# Altium schematic sheet DriverBoard.SchDoc(DriverBoard)
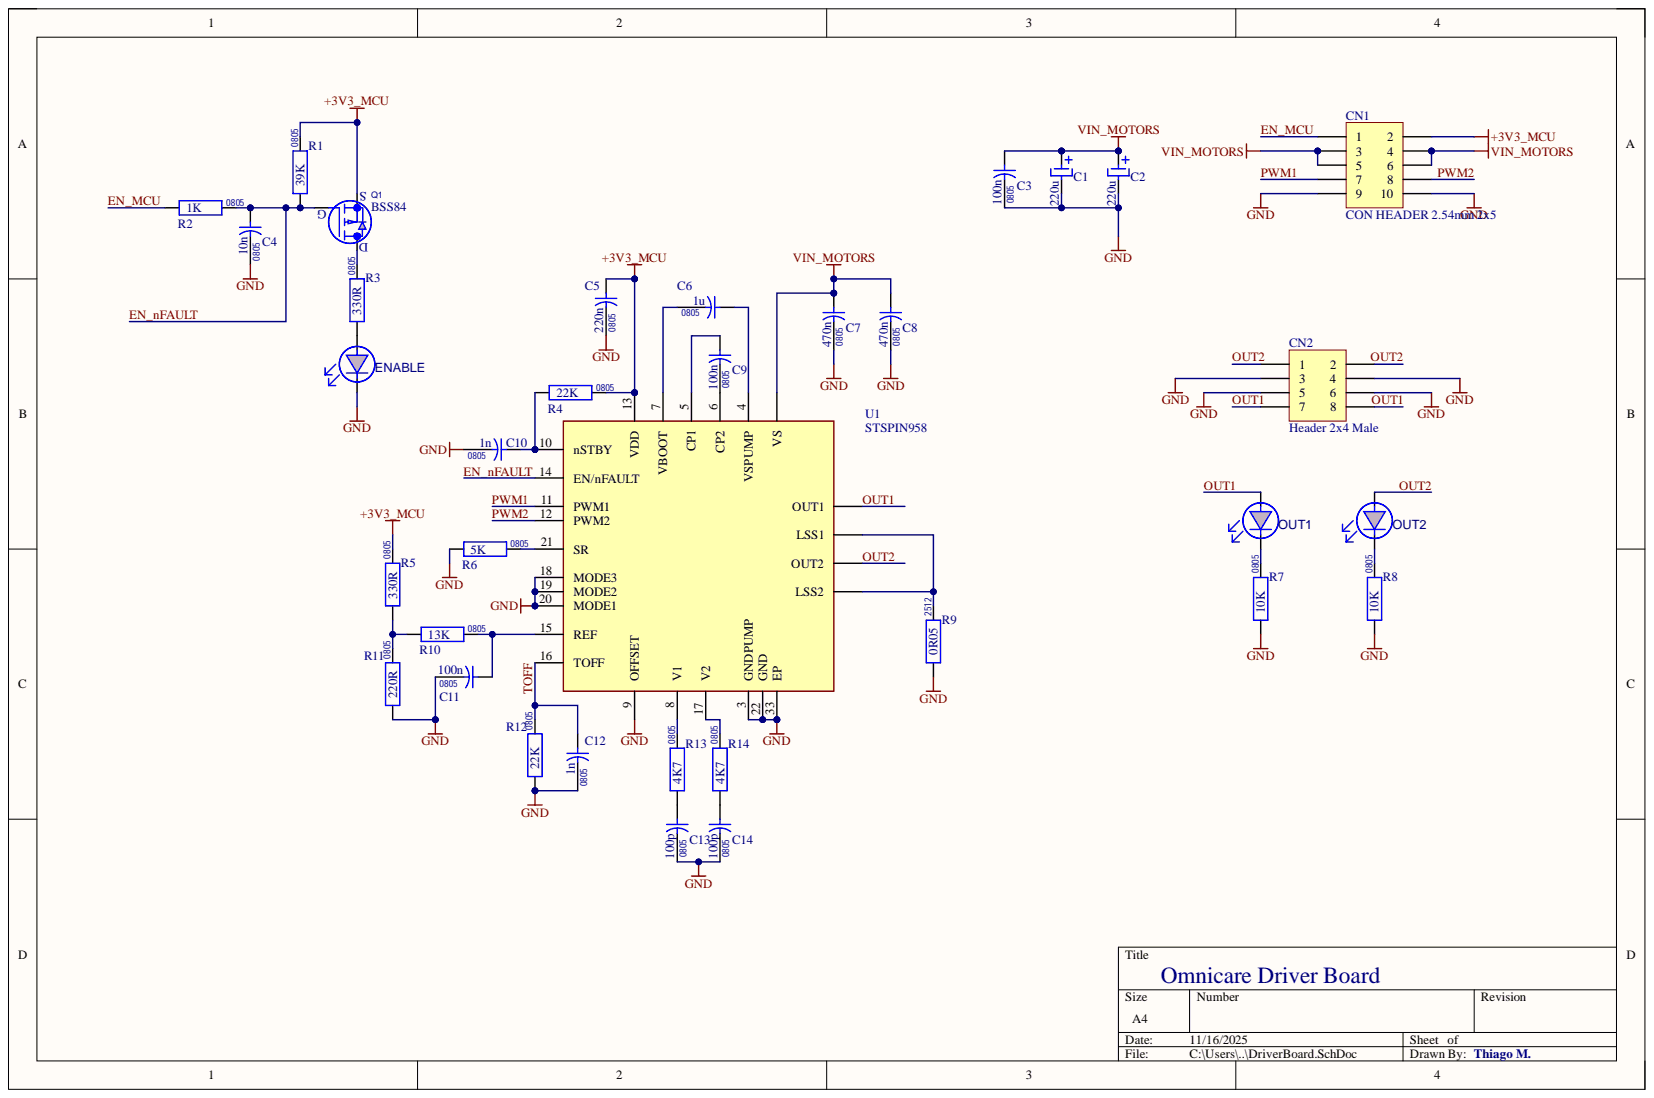
[diagram p0: DriverBoard.SchDoc]

## Parts
C1  220u  [CAP SMD ELE 10x10.2mm]
C2  220u  [CAP SMD ELE 10x10.2mm]
C3  100n  [CAP SMD CER 0805]
C4  10n  [CAP SMD CER 0805]
C5  220n  [CAP SMD CER 0805]
C6  1u  [CAP SMD CER 0805]
C7  470n  [CAP SMD CER 0805]
C8  470n  [CAP SMD CER 0805]
C9  100n  [CAP SMD CER 0805]
C10  1n  [CAP SMD CER 0805]
C11  100n  [CAP SMD CER 0805]
C12  1n  [CAP SMD CER 0805]
C13  100p  [CAP SMD CER 0805]
C14  100p  [CAP SMD CER 0805]
CN1  CON HEADER 2.54mm 2x5  [CON HEADER 2.54mm 2x5]
CN2  Header 2x4 Male  [Header 2x4 Male-Footprint-1]
ENABLE  RED  [LED SMD 0805]  (value not drawn)
OUT1  RED  [LED SMD 0805]  (value not drawn)
OUT2  RED  [LED SMD 0805]  (value not drawn)
Q1  BSS84  [SOT23]
R1  39K  [RES SMD 0805]
R2  1K  [RES SMD 0805]
R3  330R  [RES SMD 0805]
R4  22K  [RES SMD 0805]
R5  330R  [RES SMD 0805]
R6  5K  [RES SMD 0805]
R7  10K  [RES SMD 0805]
R8  10K  [RES SMD 0805]
R9  0R05  [RES SMD 2512]
R10  13K  [RES SMD 0805]
R11  220R  [RES SMD 0805]
R12  22K  [RES SMD 0805]
R13  4K7  [RES SMD 0805]
R14  4K7  [RES SMD 0805]
U1  STSPIN958  [NBG32 (VFQFPN 32)]

## Nets
+3V3_MCU: C5.1 CN1.2 Q1.2 R1.1 R4.1 R5.1 U1.13(VDD)
GND: C1.2 C2.2 C3.2 C4.2 C5.2 C7.2 C8.2 C10.2 C11.2 C12.2 C13.2 C14.2 CN1.9 CN1.10 CN2.3 CN2.4 CN2.5 CN2.6 ENABLE.2 R6.2 R7.2 R8.2 R9.2 R11.2 R12.2 U1.3(GNDPUMP) U1.9(OFFSET) U1.18(MODE3) U1.19(MODE2) U1.20(MODE1) U1.22(GND) U1.33(EP)
VIN_MOTORS: C1.1 C2.1 C3.1 C7.1 C8.1 CN1.3 CN1.4 CN1.5 CN1.6 U1.27, 28, 29, 30(VS)
EN_MCU: CN1.1 R2.2
EN_nFAULT: C4.1 Q1.1 R1.2 R2.1 U1.14(EN/nFAULT)
OUT1: CN2.7 CN2.8 OUT1.1 U1.31, 32(OUT1)
OUT2: CN2.1 CN2.2 OUT2.1 U1.25, 26(OUT2)
PWM1: CN1.7 U1.11(PWM1)
PWM2: CN1.8 U1.12(PWM2)
TOFF: C12.1 R12.1 U1.16(TOFF)
NetC6_1: C6.1 U1.4(VSPUMP)
NetC6_2: C6.2 U1.7(VBOOT)
NetC9_1: C9.1 U1.5(CP1)
NetC9_2: C9.2 U1.6(CP2)
NetC10_1: C10.1 R4.2 U1.10(nSTBY)
NetC11_1: C11.1 R10.1 U1.15(REF)
NetC13_1: C13.1 R13.2
NetC14_1: C14.1 R14.2
NetENABLE_1: ENABLE.1 R3.2
NetOUT1_2: OUT1.2 R7.1
NetOUT2_2: OUT2.2 R8.1
NetQ1_3: Q1.3 R3.1
NetR5_2: R5.2 R10.2 R11.1
NetR6_1: R6.1 U1.21(SR)
NetR9_1: R9.1 U1.1, 2(LSS1) U1.23, 24(LSS2)
NetR13_1: R13.1 U1.8(V1)
NetR14_1: R14.1 U1.17(V2)

## Library data of the parts
C1 C2 = CAP SMD - Gen. Electrolytic: Generic electrolytic capacitors for general purpose.; Component Kind: Standard; Library Name: My_Lib.IntLib; Link 1: https://tiggercomp.com.br/novaloja2/eletrolitico-smd-220uf-x-50v; Supplier 1: JLCPCB; value: 220u
C3-C14 = CAP SMD - Gen. Ceramic 0805: Generic ceramic capacitor with package 0805 for general purpose.; Component Kind: Standard; Library Name: My_Lib.IntLib
  C3 C9 C11: value: 100n
  C4: value: 10n
  C5: value: 220n
  C6: value: 1u
  C7 C8: value: 470n
  C10 C12: value: 1n
  C13 C14: value: 100p
CN2 = CMP-00003-1: Pin Header  2x4 Male 2.54mm; Component Kind: Standard; Library Name: TCC
ENABLE OUT1 OUT2 = LED SMD - Gen. 0805: LED - SMD - 0805; PN: NCD0805R1; Color: RED; Component Kind: Standard; Library Name: My_Lib.IntLib; Supplier 1: JLCPB B; Supplier Part Number 1: C84256
Q1 = BSS84: TRANSISTOR - Mosfet  P Channel - 130 mA - 50VDS - 20VGS - SOT23; Id: -130 mA; Vds: -50V; Vgso: -+20V; Vth: -0.8V to -2V; Component Kind: Standard; ComponentLink1Description: Link; ComponentLink1URL: https://produto.mercadolivre.com.br/MLB-2883944680-40x-transistor-bss84-mosfet-p-130ma-50v-13w-smd-sot23-nxp-_JM#is_advertising=true&position=1&search_layout=stack&type=pad&tracking_id=7bd20595-c5ac-4724-a440-7eddcb5d2dce&is_advertising=true&ad_domain=VQCATCORE_LST&ad_position=1&ad_click_id=MmMyMTc0MjQtYTgxYy00NGVmLTk1NWMtMzkzMzQyZjhkNDUz; Library Name: My_Lib.IntLib; PN: BSS84
R1-R8 R10-R14 = RES SMD - Gen. 0805: Generic resistor with package 0805 for general purpose; Component Kind: Standard; Library Name: My_Lib.IntLib
  R1: value: 39K
  R2: value: 1K
  R3 R5: value: 330R
  R4 R12: value: 22K
  R6: value: 5K
  R7 R8: value: 10K
  R10: value: 13K
  R11: value: 220R
  R13 R14: value: 4K7
R9 = RES SMD - Gen. 2512: Generic resistor with package 2512 for general purpose; Component Kind: Standard; Library Name: My_Lib.IntLib; value: 0R05
U1 = STSPIN958: ST Motor driver up to 58V; Component Kind: Standard; Library Name: My_Lib.IntLib
CN1 = CON HEADER 2.54mm 2x5: Component Kind: Standard; Library Name: My_Lib.IntLib
